# Altium schematic sheet Input_power.SchDoc
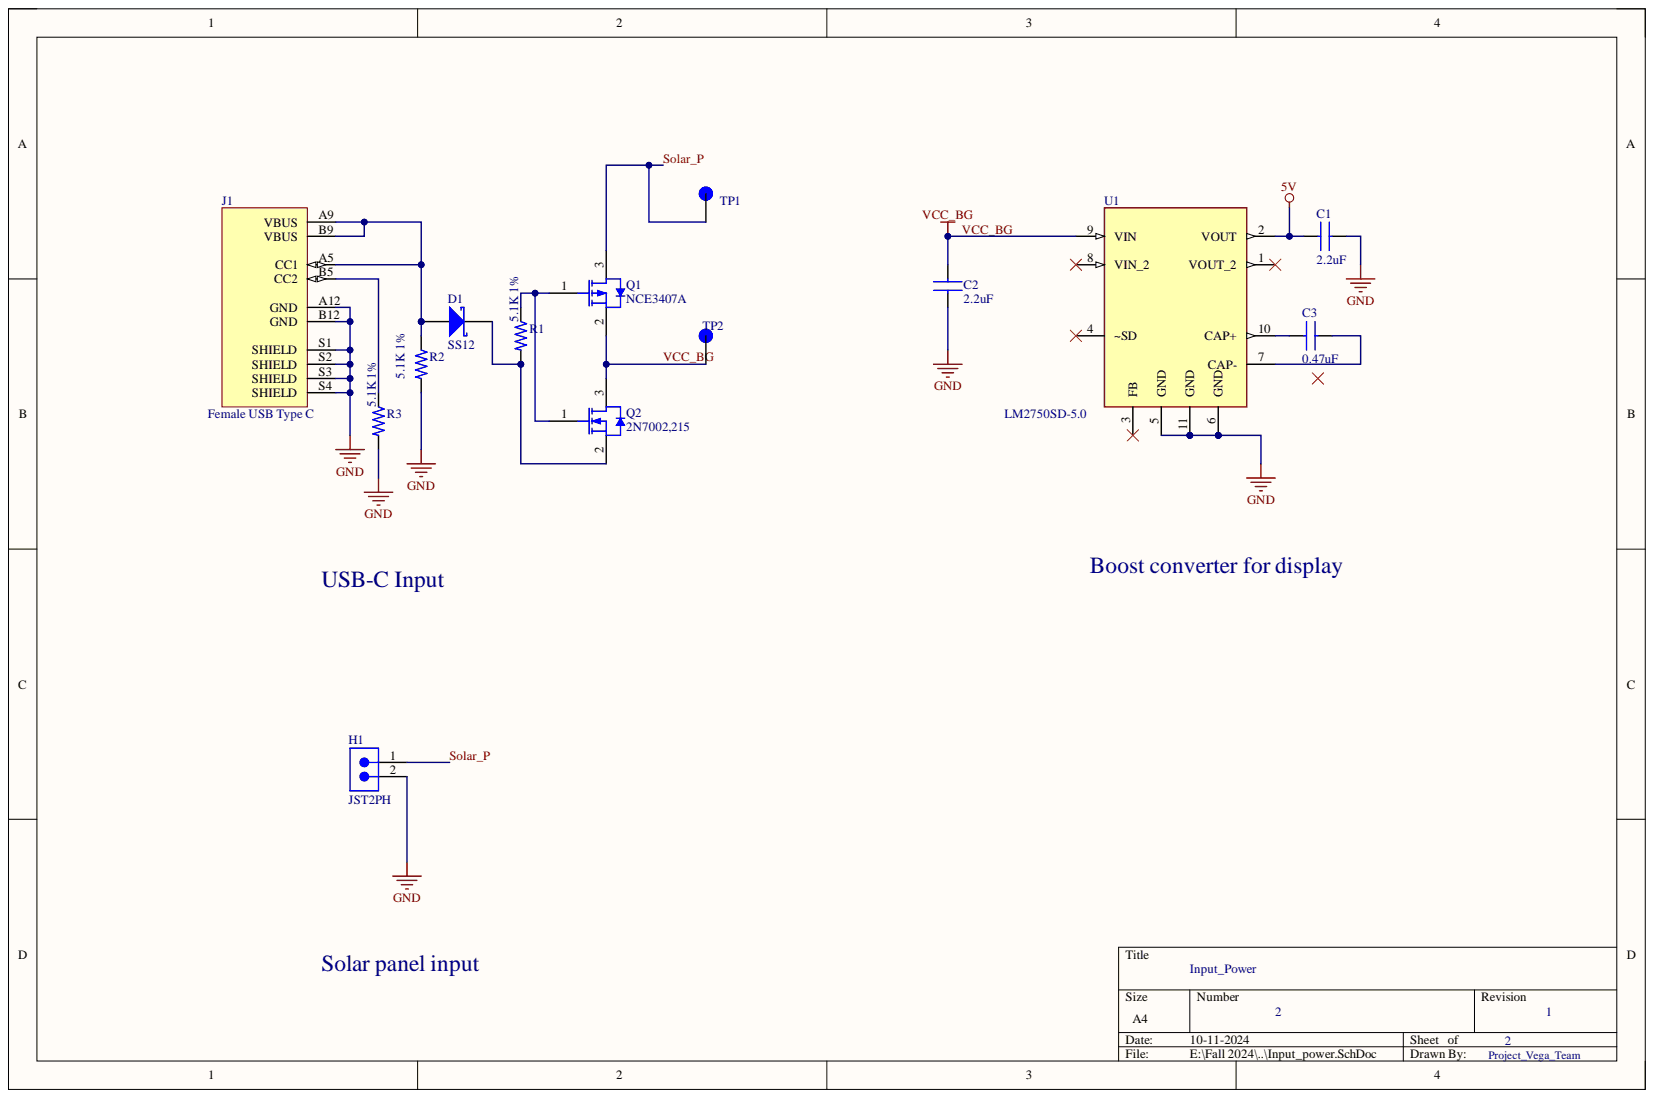
[diagram p0: Input_power.SchDoc]

## Parts
C1  2.2uF  [CAP_0603_1MM]
C2  2.2uF  [CAP_0603_1MM]
C3  0.47uF  [CAP_0603_1MM]
D1  SS12  [FAIR-DO-214AC-2_V]
H1  JST2PH  [JST_B2B-PH-K-S(LF)(SN)]
J1  Female USB Type C  [GCT_USB4125-GF-A_REVA2]
Q1  NCE3407A  [NCEP-SOT23-3_M]
Q2  2N7002,215  [NXP-SOT23-3_V]
R1  5.1K 1%  Tolerance: 1%  [RES_0603_1608X06]
R2  5.1K 1%  Tolerance: 1%  [RES_0603_1608X06]
R3  5.1K 1%  Tolerance: 1%  [RES_0603_1608X06]
TP1  Test_point  [TP]  (value not drawn)
TP2  Test_point  [TP]  (value not drawn)
U1  LM2750SD-5.0  [LM2750_DFN300X300X80-11N]

## Nets
5V: C1.2 U1.2(VOUT)
GND: C1.1 C2.1 H1.2 J1.A12(GND) J1.B12(GND) J1.S1(SHIELD) J1.S2(SHIELD) J1.S3(SHIELD) J1.S4(SHIELD) R2.2 R3.2 U1.5(GND) U1.6(GND) U1.11(GND)
Solar_P: H1.1 Q1.3 TP1.TP
VCC_BG: C2.2 Q1.2 Q2.3 TP2.TP U1.9(VIN)
NetC3_1: C3.1 U1.7(CAP-)
NetC3_2: C3.2 U1.10(CAP+)
NetD1_1: D1.1 J1.A5(CC1) J1.A9(VBUS) J1.B9(VBUS) R2.1
NetD1_2: D1.2 Q2.2 R1.2
NetJ1_B5: J1.B5(CC2) R3.1
NetQ1_1: Q1.1 Q2.1 R1.1

Not connected: U1 1(VOUT_2) 3(FB) 4(~SD) 8(VIN_2)

## Library data of the parts
C1 = GRM188R61A226ME15D: Manufacturer: Murata; Brand: Murata Electronics; Capacitance: 22 uF; Dielectric: X5R; Tolerance: 20 %; Type: Voltage and Temperature Derating Recommended Product; Unit Weight: 0.000222 oz; Voltage Rating DC: 10 VDC; Case Code - in: 0603; Case Code - mm: 1608; Component Kind: Standard; ComponentLink1Description: http://www.farnell.com/datasheets/2047978.pdf?src-supplier=Element14; ComponentLink1URL: http://www.farnell.com/datasheets/2047978.pdf?src-supplier=Element14; Description: 2.2uF; Factory Pack Quantity: 4000; Height: 0.8 mm; Length: 1.6 mm; Library Name: LPEDT_Parts.IntLib; Manufacturer Part Number: GRM188R61A226ME15D; Maximum Operating Temperature: + 85 C; Minimum Operating Temperature: - 55 C; Package / Case: 0603 (1608 metric); Packaging: Reel; Pricing 1: 1=0.33, 10=0.226, 50=0.1506, 100=0.1279, 500=0.0903, 1000=0.079 (USD); Product: General Type MLCCs; Product Category: Multilayer Ceramic Capacitors MLCC - SMD/SMT; RoHS: True; Series: GRM; Stock 1: 849458; Supplier 1: Digi-Key; Supplier Part Number 1: 490-10476-1-ND; Termination Style: SMD/SMT; Width: 0.8 mm
C2 C3 = GRM188R61A226ME15D: CAP CER 22UF 10V X5R 0603; Manufacturer: Murata; Brand: Murata Electronics; Tolerance: 20 %; Type: Voltage and Temperature Derating Recommended Product; Unit Weight: 0.000222 oz; Voltage Rating DC: 10 VDC; Capacitance: 22 uF; Case Code - in: 0603; Case Code - mm: 1608; Component Kind: Standard; ComponentLink1Description: http://www.farnell.com/datasheets/2047978.pdf?src-supplier=Element14; ComponentLink1URL: http://www.farnell.com/datasheets/2047978.pdf?src-supplier=Element14; Dielectric: X5R; Factory Pack Quantity: 4000; Height: 0.8 mm; Length: 1.6 mm; Library Name: LPEDT_Parts.IntLib; Manufacturer Part Number: GRM188R61A226ME15D; Maximum Operating Temperature: + 85 C; Minimum Operating Temperature: - 55 C; Package / Case: 0603 (1608 metric); Packaging: Reel; Pricing 1: 1=0.33, 10=0.226, 50=0.1506, 100=0.1279, 500=0.0903, 1000=0.079 (USD); Product: General Type MLCCs; Product Category: Multilayer Ceramic Capacitors MLCC - SMD/SMT; RoHS: True; Series: GRM; Stock 1: 849458; Supplier 1: Digi-Key; Supplier Part Number 1: 490-10476-1-ND; Termination Style: SMD/SMT; Width: 0.8 mm
D1 = CMP-004-00016-2: Schottky Rectifier, 20 V, 1 A, -65 to 125 degC, 2-Pin DO-214AC, RoHS, Tape and Reel; Manufacturer: Fairchild; Forward Voltage: 500mV; Max Surge Current: 40A; Peak Reverse Current: 200uA; Case/Package: SMA; Component Kind: Standard; Datasheet URL: https://www.fairchildsemi.com/datasheets/SS/SS12.pdf; Datasheet Version: Rev. 1.9, 01/2015; Manufacturer URL: https://www.fairchildsemi.com/; Max Operating Temperature: 125°C; Min Operating Temperature: -65°C; Mounting Technology: SM; Package Description: 2-Pin Surface Mount Device, Body 4.375 x 2.725 mm; Package Quantity: 7500; Package Reference: DO-214AC-2; Package URL: https://www.fairchildsemi.com/package-drawings/DO/DO214AC.pdf; Packaging: TapeandReel; Pins: 2; RoHS Compliant: True; Library Name: University of Colorado Boulder
H1 = JST2PH: Connector Header Through Hole 2 position 0.079" (2.00mm); Manufacturer: JST; Manufacturer Part Number: B2B-PH-K-S; Category: Connectors; Component Kind: Standard; ComponentLink1Description: https://datasheet.ciiva.com/6905/phseries-6905929.pdf?src-supplier=Digi-Key; ComponentLink1URL: https://datasheet.ciiva.com/6905/phseries-6905929.pdf?src-supplier=Digi-Key; Library Name: Connectors.IntLib; Pricing: 1=0.19, 10=0.147, 100=0.1088, 500=0.09006, 1000=0.0788, 5000=0.06754, 10000=0.06567 (USD); RoHS: Yes; Stock: 1077617; Supplier: Digi-Key; Supplier Part Number: 455-1704-ND
J1 = USB-C Power Connector: USB-C (USB TYPE-C) Receptacle Connector 24 (6+18 Dummy) Position Surface Mount, Right Angle; Through Hole; Manufacturer Part Number: USB4125-GF-A_REVA2; Availability: Unavailable; Component Kind: Standard; Library Name: Connectors.IntLib; Package: None; Price: None
Q1 = CMP-013-00018-3: P-Channel Enhancement Mode Power MOSFET, 30 V, 4.3 A, - 55 to 150 degC, 3-Pin SOT23, RoHS, Tape and Reel; Manufacturer: NCE Power; Case/Package: SOT23-3; Component Kind: Standard; Datasheet URL: http://www.ncepower.com/Upload/MOSFET/mos1/55vpmos/NCE3407Adatasheet-17275285683.pdf; Datasheet Version: Rev. 1.1, 07/2014; Mounting Technology: SM; Package Description: 3-Pin Small Outline Transistor, Body 2.9 x 1.3 mm, Pitch 0.95 mm; Package Reference: SOT23-3; RoHS Compliant: Unknown; Library Name: University of Colorado Boulder
Q2 = CMP-013-00011-4: N-channel Trench MOSFET, 60 V, 300 mA, -65 to 150 degC, 3-Pin SOT-23 (TO-236AB), RoHS, Tape and Reel; Manufacturer: NXP Semiconductors; Elements: 1; Input Capacitance: 50pF; Max Dual Supply Voltage: 60V; Nominal Vgs: 2V; Package Version: Feb-16; Power: 830mW; Rds On Max: 5Ohm; Case/Package: TO-236-3; Component Kind: Standard; Datasheet URL: http://www.nxp.com/documents/data_sheet/2N7002.pdf; Datasheet Version: Rev. 7, 09/2011; Max Operating Temperature: 150°C; Min Operating Temperature: -65°C; Mounting Technology: SM; Nominal Dual Supply Voltage: 60V; Package Description: 3-Pin Small Outline Transistor, Body 1.3 x 2.9 mm, Pitch 0.95 mm; Package Quantity: 1; Package Reference: SOT23-3; Packaging: TapeandReel; Pins: 8; RoHS Compliant: True; Vds Max: 60V; Library Name: University of Colorado Boulder
R1 R3 = RC0603FR-07316KL: SMD Chip Resistor, 5.1KOhm, ± 1%, 100 mW, 0603 [1608 Metric], Thick Film, General Purpose; Manufacturer: Yageo; Max Power Dissipation: 100mW; Temperature Coefficient: 100ppm/°C; Value: 316kR; Voltage Rating: 50V; Case Code (Imperial): 0603; Case Code (Metric): 1608; Case/Package: 0603; Category: Chip SMD Resistors; Component Kind: Standard; ComponentLink1Description: https://datasheet.ciiva.com/2454/pyu-r-int-thick-7-2454966.pdf?src-supplier=Digikey; ComponentLink1URL: https://datasheet.ciiva.com/2454/pyu-r-int-thick-7-2454966.pdf?src-supplier=Digikey; ComponentLink2Description: https://datasheet.ciiva.com/2454/pyu-r-int-thick-7-2454967.pdf?src-supplier=Digikey; ComponentLink2URL: https://datasheet.ciiva.com/2454/pyu-r-int-thick-7-2454967.pdf?src-supplier=Digikey; ComponentLink3Description: https://datasheet.ciiva.com/2454/pyu-r-int-thick-7-2454968.pdf?src-supplier=Digikey; ComponentLink3URL: https://datasheet.ciiva.com/2454/pyu-r-int-thick-7-2454968.pdf?src-supplier=Digikey; Features: Moisture Resistant; Lead Free: Lead Free; Library Name: LPEDT_Parts.IntLib; Manufacturer Part Number: RC0603FR-07316KL; Max Operating Temperature: 155°C; Min Operating Temperature: -55°C; Mounting Technology: Surface Mount; Number of Terminations: 2; Packaging: Tape and Reel; Power Rating: 100mW; Pricing: 1=0.1, 10=0.019, 50=0.0112, 100=0.0093, 500=0.00622, 1000=0.00532 (USD); RoHS: Yes; RoHS Compliant: Yes; Series: RC; Stock: 149463; Supplier: Digikey; Supplier Part Number: 311-316KHRCT-ND; Termination: SMD/SMT; Voltage Rating (DC): 50V
R2 = RC0603FR-07316KL: SMD Chip Resistor, 316 kOhm, ± 1%, 100 mW, 0603 [1608 Metric], Thick Film, General Purpose; Manufacturer: Yageo; Max Power Dissipation: 100mW; Temperature Coefficient: 100ppm/°C; Value: 316kR; Voltage Rating: 50V; Case Code (Imperial): 0603; Case Code (Metric): 1608; Case/Package: 0603; Category: Chip SMD Resistors; Component Kind: Standard; ComponentLink1Description: https://datasheet.ciiva.com/2454/pyu-r-int-thick-7-2454966.pdf?src-supplier=Digikey; ComponentLink1URL: https://datasheet.ciiva.com/2454/pyu-r-int-thick-7-2454966.pdf?src-supplier=Digikey; ComponentLink2Description: https://datasheet.ciiva.com/2454/pyu-r-int-thick-7-2454967.pdf?src-supplier=Digikey; ComponentLink2URL: https://datasheet.ciiva.com/2454/pyu-r-int-thick-7-2454967.pdf?src-supplier=Digikey; ComponentLink3Description: https://datasheet.ciiva.com/2454/pyu-r-int-thick-7-2454968.pdf?src-supplier=Digikey; ComponentLink3URL: https://datasheet.ciiva.com/2454/pyu-r-int-thick-7-2454968.pdf?src-supplier=Digikey; Features: Moisture Resistant; Lead Free: Lead Free; Library Name: LPEDT_Parts.IntLib; Manufacturer Part Number: RC0603FR-07316KL; Max Operating Temperature: 155°C; Min Operating Temperature: -55°C; Mounting Technology: Surface Mount; Number of Terminations: 2; Packaging: Tape and Reel; Power Rating: 100mW; Pricing: 1=0.1, 10=0.019, 50=0.0112, 100=0.0093, 500=0.00622, 1000=0.00532 (USD); RoHS: Yes; RoHS Compliant: Yes; Series: RC; Stock: 149463; Supplier: Digikey; Supplier Part Number: 311-316KHRCT-ND; Termination: SMD/SMT; Voltage Rating (DC): 50V
TP1 TP2 = Test_point: SMT Test Point- Mininature-1000 pc Reels; MF: Keystone Electronics; MP: 5019; Availability: In Stock; Component Kind: Standard; Package: None; Price: None; Purchase-URL: https://pricing.snapeda.com/search/part/5019/?ref=eda; Library Name: Project_Vega.IntLib
U1 = LM2750SD-5.0: IC CONV REG SW CAP 5V; MP: LM2750SD-5-0; Availability: Unavailable; Component Kind: Standard; Package: None; Price: None; Supplier 1: Digikey; Supplier Part Number 1: LM2750SD-5.0/NOPBCT-ND; Library Name: Project_Vega.IntLib
